# Altium schematic sheet Extra_Drives.SchDoc(Extra_Drives)
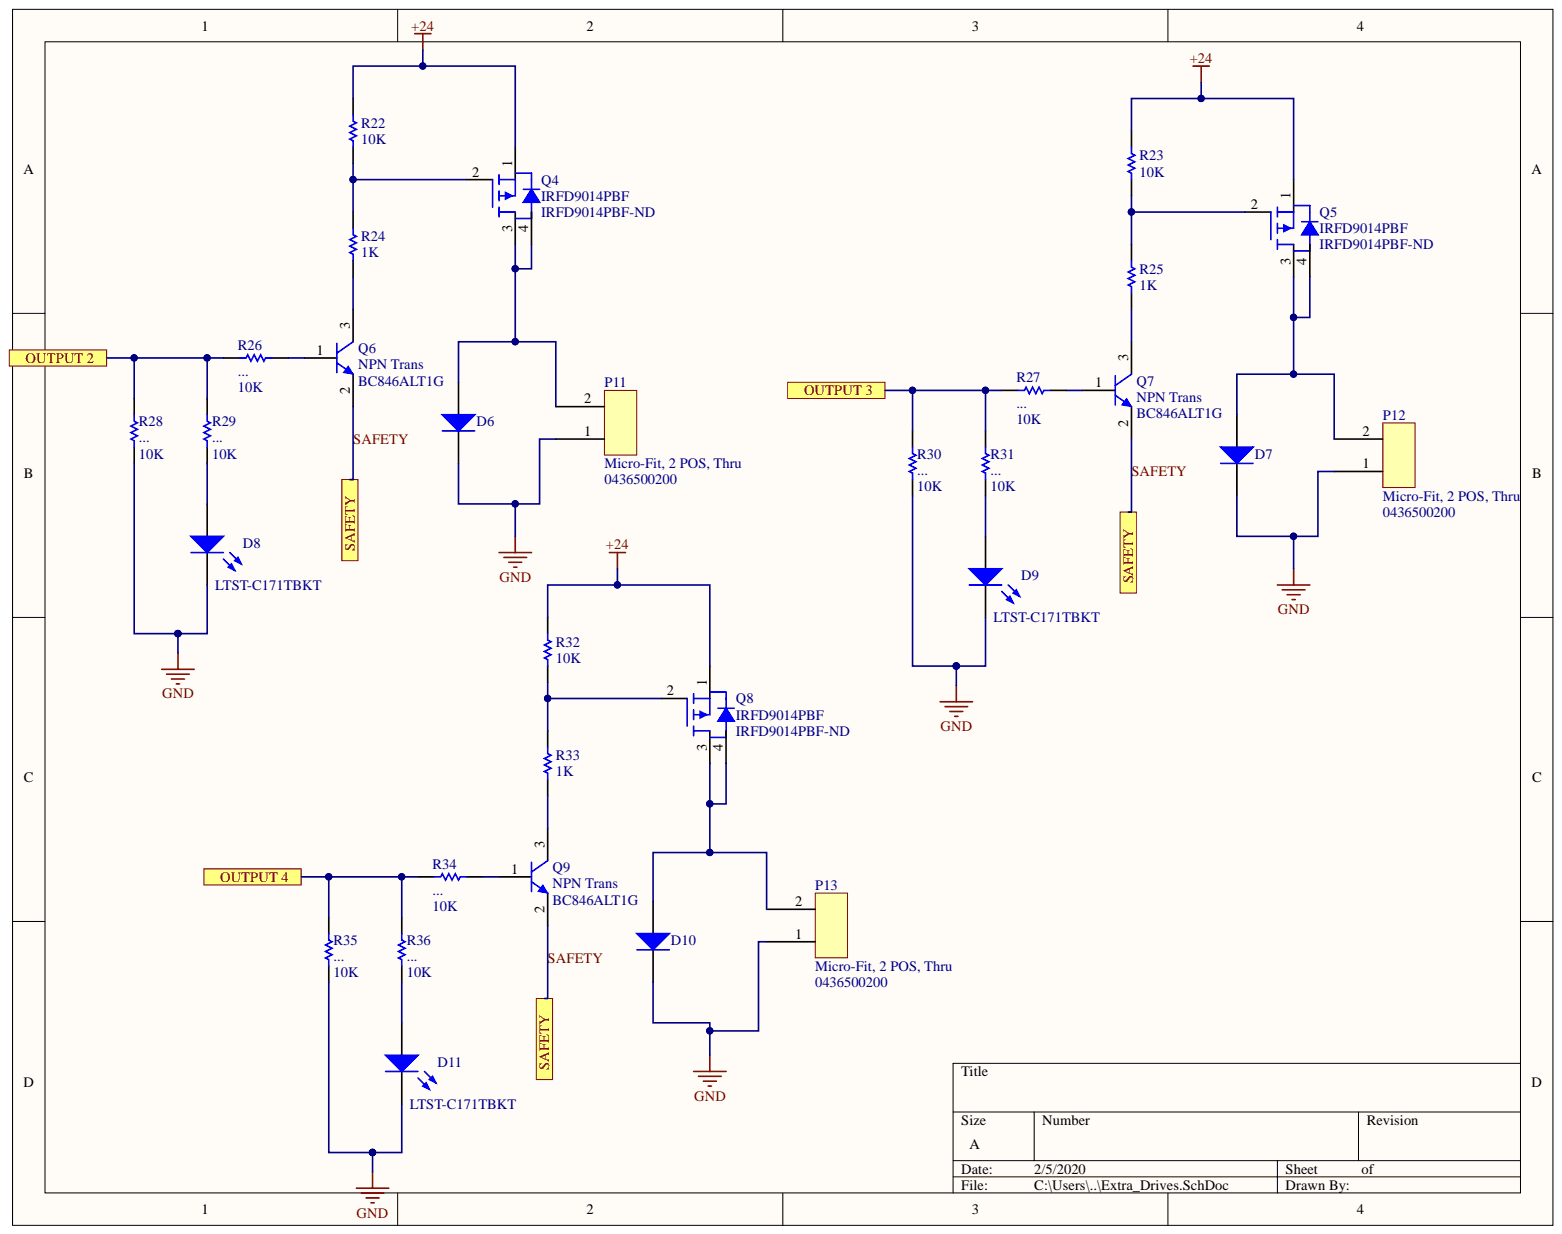
[diagram p0: Extra_Drives.SchDoc]

## Parts
D6  Diode 1N4001  [DO-41]  (value not drawn)
D7  Diode 1N4001  [DO-41]  (value not drawn)
D8  LTST-C171TBKT  [DIOC2011X08M]
D9  LTST-C171TBKT  [DIOC2011X08M]
D10  Diode 1N4001  [DO-41]  (value not drawn)
D11  LTST-C171TBKT  [DIOC2011X08M]
P11  Micro-Fit, 2 POS, Thru  Manufacturer Part Number 1: 0436500200  [Molex 43650-0200]
P12  Micro-Fit, 2 POS, Thru  Manufacturer Part Number 1: 0436500200  [Molex 43650-0200]
P13  Micro-Fit, 2 POS, Thru  Manufacturer Part Number 1: 0436500200  [Molex 43650-0200]
Q4  IRFD9014PBF  Supplier Part Number 1: IRFD9014PBF-ND  [HVMDIP]
Q5  IRFD9014PBF  Supplier Part Number 1: IRFD9014PBF-ND  [HVMDIP]
Q6  NPN Trans  Polarity: NPN  [SOT95P237X110-3M]
Q7  NPN Trans  Polarity: NPN  [SOT95P237X110-3M]
Q8  IRFD9014PBF  Supplier Part Number 1: IRFD9014PBF-ND  [HVMDIP]
Q9  NPN Trans  Polarity: NPN  [SOT95P237X110-3M]
R22  10K  [RES_0805]
R23  10K  [RES_0805]
R24  1K  [RES_0805]
R25  1K  [RES_0805]
R26  ...  value 10K  [RES_0805]
R27  ...  value 10K  [RES_0805]
R28  ...  value 10K  [RES_0805]
R29  ...  value 10K  [RES_0805]
R30  ...  value 10K  [RES_0805]
R31  ...  value 10K  [RES_0805]
R32  10K  [RES_0805]
R33  1K  [RES_0805]
R34  ...  value 10K  [RES_0805]
R35  ...  value 10K  [RES_0805]
R36  ...  value 10K  [RES_0805]

## Ports
OUTPUT 2, OUTPUT 3, OUTPUT 4, SAFETY

## Nets
+24: Q4.1 Q5.1 Q8.1 R22.2 R23.2 R32.2
GND: D6.2 D7.2 D8.2 D9.2 D10.2 D11.2 P11.1 P12.1 P13.1 R28.1 R30.1 R35.1
OUTPUT 2: R26.1 R28.2 R29.1 ; port OUTPUT 2
OUTPUT 3: R27.1 R30.2 R31.1 ; port OUTPUT 3
OUTPUT 4: R34.1 R35.2 R36.1 ; port OUTPUT 4
SAFETY: Q6.2 Q7.2 Q9.2 ; port SAFETY
NetD6_1: D6.1 P11.2 Q4.3 Q4.4
NetD7_1: D7.1 P12.2 Q5.3 Q5.4
NetD8_1: D8.1 R29.2
NetD9_1: D9.1 R31.2
NetD10_1: D10.1 P13.2 Q8.3 Q8.4
NetD11_1: D11.1 R36.2
NetQ4_2: Q4.2 R22.1 R24.2
NetQ5_2: Q5.2 R23.1 R25.2
NetQ6_1: Q6.1 R26.2
NetQ6_3: Q6.3 R24.1
NetQ7_1: Q7.1 R27.2
NetQ7_3: Q7.3 R25.1
NetQ8_2: Q8.2 R32.1 R33.2
NetQ9_1: Q9.1 R34.2
NetQ9_3: Q9.3 R33.1

## Library data of the parts
D6 D7 D10 = Diode 1N4001: 1 Amp General Purpose Rectifier; Manufacturer: ON Semiconductor / Fairchild; Voltage Rating (DC): 50V; Power Dissipation: 3W; Polarity: Standard; Peak Reverse Current: 5uA; Peak Non-Repetitive Surge Current: 30A; Output Current: 1A; Forward Voltage: 1.1V; Element Configuration: Single; Capacitance: 15pF; Width: 0.25inch; Weight: 0.245g; Supplier Part Number 1: 1N4001FSCT-ND; Supplier 1: Digi-Key; Stock 1: 0; Simulation: 1N4001; Signal Integrity: 1N4001; Reverse Voltage: 50V; REACH SVHC: No SVHC; Radiation Hardening: No; Published: 8-Jun-2000; Pricing 1: 1=0.17 (USD); Packaging: Tape and Reel; PackageVersion: Aug-1999; PackageReference: DO-41; Package Quantity: 1000; PackageDescription: Diode, Axial; Body 5.2 x 2.7 mm (LxDia. max), Lead Dia. 0.86 mm (max); Number of Pins: 2; Mount: Through Hole; Min Operating Temperature: -55°C; Max Surge Current: 30A; Max Reverse Voltage (DC): 50V; Max Repetitive Reverse Voltage (Vrrm): 50V; Max Operating Temperature: 175°C; Max Forward Surge Current (Ifsm): 30A; Manufacturer Part Number: 1N4001; Library Name: Passives_custom.SchLib; Length: 5.2mm; Lead Free: Lead Free; LatestRevisionNote: Re-released for DXP Platform.; LatestRevisionDate: 17-Jul-2002; Height: 5.2mm; Forward Current: 1A; Diameter: 2.72mm; DatasheetVersion: 1998; Current Rating: 1A; Contact Plating: Matte,Tin; ComponentLink9URL: http://www.farnell.com/datasheets/1923868.pdf?src-supplier=Element14; ComponentLink9Description: http://www.farnell.com/datasheets/1923868.pdf?src-supplier=Element14; ComponentLink8URL: https://www.verical.com/server-webapp/html/getDatasheet?partID=148723&src-supplier=Verical; ComponentLink8Description: https://www.verical.com/server-webapp/html/getDatasheet?partID=148723&src-supplier=Verical; ComponentLink7URL: http://www.farnell.com/datasheets/1504060.pdf?src-supplier=Element14; ComponentLink7Description: http://www.farnell.com/datasheets/1504060.pdf?src-supplier=Element14; ComponentLink6URL: http://uk.rs-online.com/webdocs/137d/0900766b8137d734.pdf?src-supplier=RSComponents; ComponentLink6Description: http://uk.rs-online.com/webdocs/137d/0900766b8137d734.pdf?src-supplier=RSComponents; ComponentLink5URL: http://www.mouser.com/ds/2/149/1N4002-81693.pdf?src-supplier=Mouser; ComponentLink5Description: http://www.mouser.com/ds/2/149/1N4002-81693.pdf?src-supplier=Mouser; ComponentLink4URL: https://www.fairchildsemi.com/datasheets/1N/1N4007.pdf?src-supplier=Avnet; ComponentLink4Description: https://www.fairchildsemi.com/datasheets/1N/1N4007.pdf?src-supplier=Avnet; ComponentLink3URL: http://www.onsemi.com/pub/Collateral/1N4001-D.PDF?src-supplier=Digi-Key; ComponentLink3Description: http://www.onsemi.com/pub/Collateral/1N4001-D.PDF?src-supplier=Digi-Key; ComponentLink2URL: http://www.fairchildsemi.com/ds/1N%2F1N4001.pdf; ComponentLink2Description: Datasheet; ComponentLink1URL: http://www.fairchildsemi.com/; ComponentLink1Description: Manufacturer Link; Component Kind: Standard; Code_JEDEC: DO-204-AL; Average Rectified Current: 1A; Publisher: Altium Limited
D8 D9 D11 = LTST-C171TBKT: LED Uni-Color Blue 468nm 2-Pin Chip LED T/R; Manufacturer: Vishay Lite-On; Viewing Angle: 130°; Test Current: 20mA; Reverse Voltage: 5V; Power Dissipation: 76mW; Number of LEDs: 1; Luminous Intensity: 180mcd; Lens Transparency: Clear; Lens Style: Clear,Colorless,Diffused,Rectangular; Lens Color: Clear,Colorless; Forward Voltage: 3.8V; Dominant Wavelength: 475nm; Width: 1.25mm; Wavelength: 468nm; Supplier 1: Digi-Key; Stock 1: 426824; Radiation Hardening: No; Pricing 1: 1=0.5, 10=0.36, 25=0.2764, 100=0.1802, 250=0.13212, 500=0.11212, 1000=0.09209 (USD); Packaging: Tape and Reel; Package Quantity: 1; Number of Pins: 2; Number of Elements: 1; Mount: Surface Mount; Min Operating Temperature: -20°C; Max Operating Temperature: 80°C; Manufacturer Part Number: LTST-C171TBKT; Library Name: Passives_CE.SchLib; Length: 2mm; Lead Free: Lead Free; Illumination Color: Blue; Height: 0.8mm; Forward Current: 20mA; Depth: 1.25mm; ComponentLink5URL: http://www1.futureelectronics.com/doc/LITE%20ON/LITE-ON%20LTST-C171TBKT.pdf; ComponentLink5Description: http://www1.futureelectronics.com/doc/LITE%20ON/LITE-ON%20LTST-C171TBKT.pdf; ComponentLink4URL: https://www.verical.com/server-webapp/html/getDatasheet?partID=225754; ComponentLink4Description: https://www.verical.com/server-webapp/html/getDatasheet?partID=225754; ComponentLink3URL: http://www.mouser.com/ds/2/239/Lite-On-LTST-C171TBKT-187246.pdf; ComponentLink3Description: http://www.mouser.com/ds/2/239/Lite-On-LTST-C171TBKT-187246.pdf; ComponentLink2URL: http://uk.rs-online.com/webdocs/0dc0/0900766b80dc029b.pdf; ComponentLink2Description: http://uk.rs-online.com/webdocs/0dc0/0900766b80dc029b.pdf; ComponentLink1URL: http://optoelectronics.liteon.com/upload/download/DS22-2000-233/LTST-C171TBKT(0630).pdf; ComponentLink1Description: http://optoelectronics.liteon.com/upload/download/DS22-2000-233/LTST-C171TBKT(0630).pdf; Component Kind: Standard; Color: Blue; Case Code (Metric): 2012; Case Code (Imperial): 0805; Case/Package: 0805
P11-P13 = Micro-Fit, 2 POS, Thru: CONN HEADER R/A 2POS 3MM; Manufacturer 1: Molex; Number of Positions: 2; Number of Ports: 1; Contact Resistance: 10mR; Connector Type: Male Pin; Termination: Solder; Supplier Part Number 1: WM1860-ND; Supplier 1: Digi-Key; Stock 1: 19425; RoHS: Yes; Pricing 1: 1=0.96000, 10=0.84100, 100=0.72560, 500=0.59364, 1000=0.52768, 5000=0.46172, 10000=0.43863 (USD); Pitch: 3mm; Packaging: Tray; Orientation: Right Angle; Number of Terminals: 2; Number of Rows: 1; Number of Contacts: 2; Mount: Through Hole; Min Operating Temperature: -40°C; Max Operating Temperature: 105°C; Library Name: Connectors_CE.SchLib; Length: 9.65mm; Housing Material: Polymer; Housing Color: Black; Flammability Rating: UL94 V-0; Fastening Type: Ramp; Depth: 9.9mm; Contact Plating: Tin; Contact Material: Brass; ComponentLink1URL: https://www.molex.com/pdm_docs/sd/436500200_sd.pdf; ComponentLink1Description: Molex; Component Kind: Standard; Color: Black
Q4 Q5 Q8 = IRFD9014PBF: Trans MOSFET P-CH 60V 1.1A 4-Pin HVMDIP; Manufacturer 1: Vishay; Turn-On Delay Time: 11ns; Turn-Off Delay Time: 10ns; Threshold Voltage: -4V; Row Spacing: 7.62mm; Rise Time: 63ns; Rds On Max: 500mR; Power Dissipation: 1.3W; Number of Elements: 1; Input Capacitance: 270pF; Gate to Source Voltage (Vgs): 20V; Element Configuration: Single; Dual Supply Voltage: -60V; Width: 0.197inch; Supplier 1: Digi-Key; Stock 1: 1966; RoHS: Yes; REACH SVHC: No SVHC; Radiation Hardening: No; Pricing 1: 1=0.83000, 10=0.74400, 100=0.52960, 500=0.44892, 1000=0.36569, 2500=0.36065, 5000=0.32786 (USD); Packaging: Rail/Tube; Package Quantity: 2500; On-State Resistance: 500mR; Number of Pins: 4; Nominal Vgs: -4V; Mount: Through Hole; Min Operating Temperature: -55°C; Max Power Dissipation: 1.3W; Max Operating Temperature: 175°C; Manufacturer Part Number 1: IRFD9014PBF; Library Name: Passives_CE.SchLib; Length: 0.247inch; Lead Pitch: 2.54mm; Lead Free: Lead Free; Height: 0.133inch; Fall Time: 63ns; Drain to Source Voltage (Vdss): 60V; Drain to Source Resistance: 500mR; Drain to Source Breakdown Voltage: 60V; Continuous Drain Current (ID): 1.1A; ComponentLink1URL: http://www.vishay.com/docs/91136/sihfd901.pdf; ComponentLink1Description: Vishay Web; Component Kind: Standard; Case/Package: DIP
Q6 Q7 Q9 = BC846ALT1G: TRANS NPN 65V 0.1A SOT23; Manufacturer 1: ON Semiconductor; Transition Frequency: 100MHz; Power Dissipation: 300mW; Number of Elements: 1; Max Collector Current: 100mA; hFE Min: 110; Emitter Base Voltage (VEBO): 6V; Element Configuration: Single; Collector Emitter Saturation Voltage: 600mV; Collector Base Voltage (VCBO): 80V; Width: 1.3mm; Voltage Rating (DC): 65V; Supplier Part Number 1: BC846ALT1GOSCT-ND; Supplier 1: Digi-Key; Stock 1: 322425; RoHS: Yes; REACH SVHC: No SVHC; Radiation Hardening: No; Pricing 1: 1=0.12000, 10=0.11200, 100=0.04700, 500=0.03694, 1000=0.02565 (USD); Packaging: Tape and Reel; Package Quantity: 1; Number of Pins: 3; Min Operating Temperature: -55°C; Max Power Dissipation: 300mW; Max Operating Temperature: 150°C; Max Frequency: 100MHz; Max Breakdown Voltage: 65V; Manufacturer Part Number 1: BC846ALT1G; Library Name: Passives_CE.SchLib; Length: 2.9mm; Lead Free: Lead Free; Height: 0.94mm; Halogen Free: Halogen Free; Gain Bandwidth Product: 100MHz; Frequency: 100MHz; Current Rating: 100mA; Contact Plating: Tin,Matte; ComponentLink1URL: https://www.mouser.com/datasheet/2/308/BC846ALT1-D-1293035.pdf; ComponentLink1Description: Mouser; Component Kind: Standard; Collector Emitter Voltage (VCEO): 65V; Collector Emitter Breakdown Voltage: 65V; Case/Package: SOT-23-3
R22-R25 R32 R33 = 10K Resistor 0805: RES 1% 1/8W 0805; Simulation: RESISTOR; Signal Integrity: Res; PackageReference: 0805; Library Name: Passives_custom.SchLib; Component Kind: Standard
  R22 R23 R32: Value: 10K
  R24 R25 R33: Value: 1K
R26-R31 R34-R36 = RESISTOR, 0805: RES 1% 1/8W 0805; Simulation: RESISTOR; Signal Integrity: Res; PackageReference: 0805; Library Name: Passives_CE.SchLib; Component Kind: Standard
